# Altium schematic sheet main.SchDoc(main)
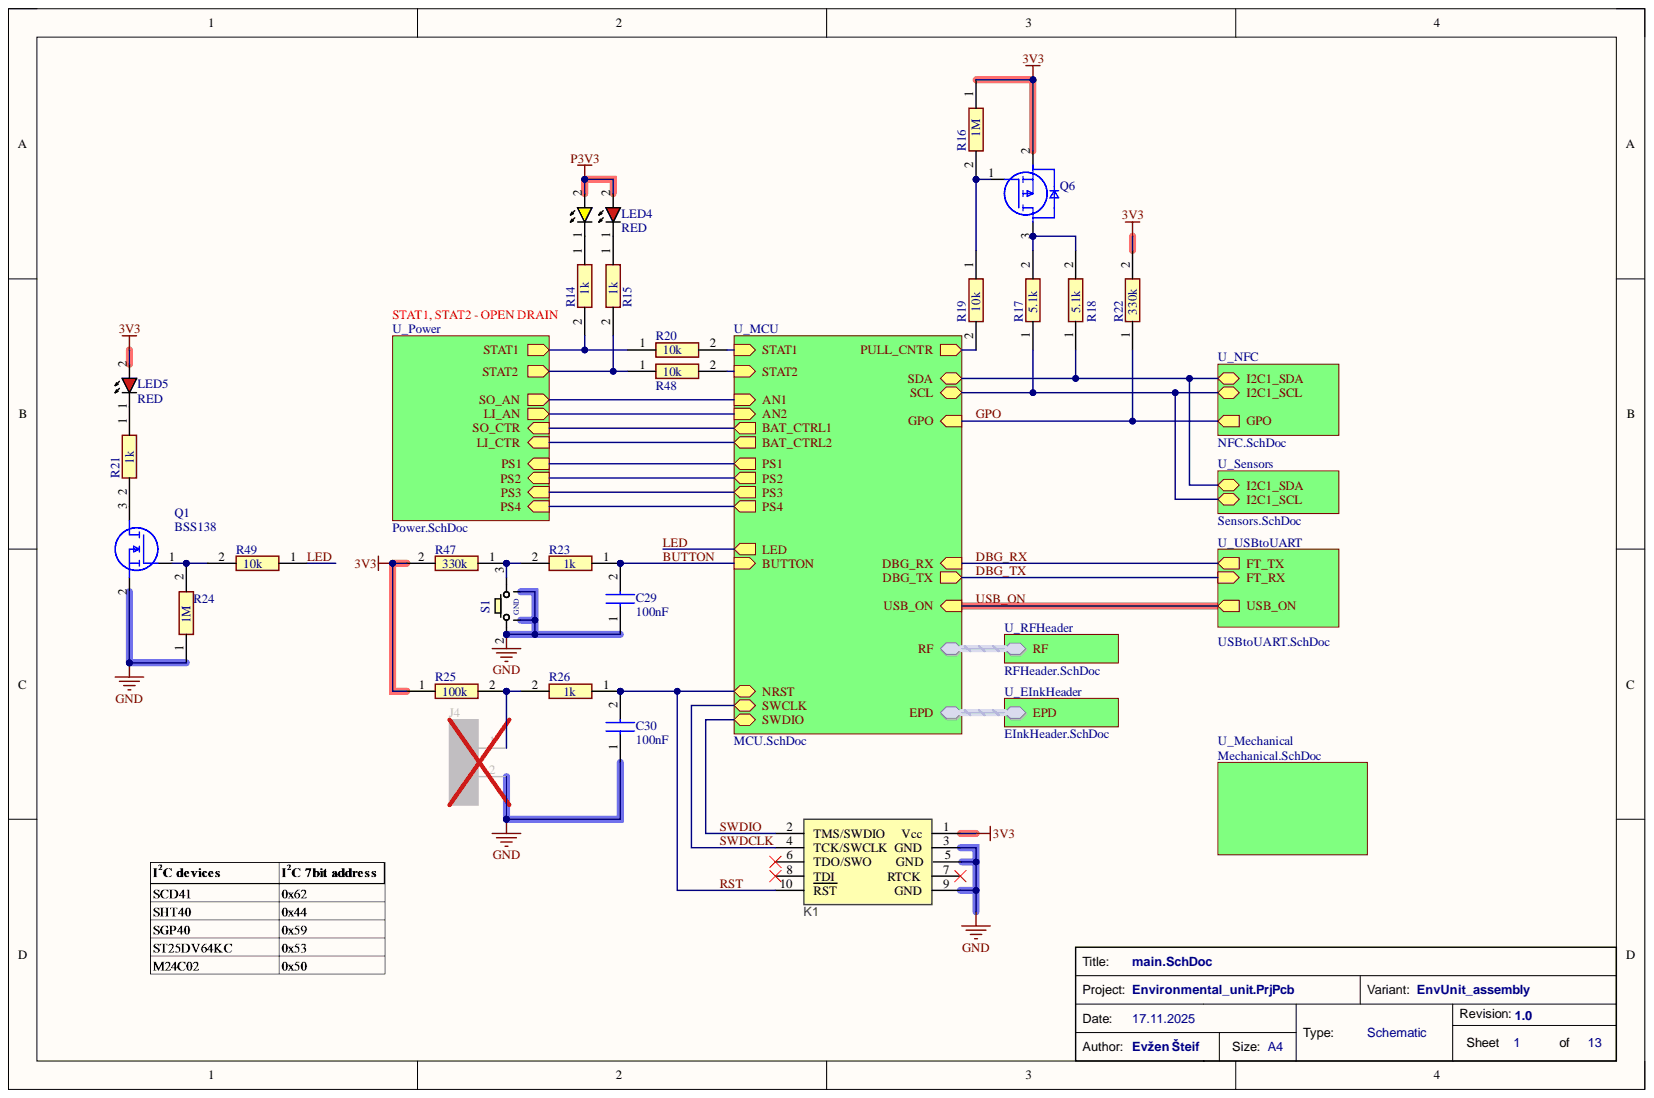
[diagram p0: main.SchDoc]

## Parts
C29  100nF  [CAPC1005X70N]
C30  100nF  [CAPC1005X70N]
J4  M20-9990246  [FP-M20-9990246-MFG]  (value not drawn)
K1  3220-10-0100-00  [TH_CON_MHDR2X5_PIT50_KEY]  (value not drawn)
LED3  150060YS75000  [LEDC1608X80N]  (value not drawn)
LED4  RED  [LEDC1608X80N]
LED5  RED  [LEDC1608X80N]
Q1  BSS138  [SOT95P240X120-3N]
Q6  SSM3J358R,LF  [SOTFL95P240X88-3N]  (value not drawn)
R14  1k  [RESC1005X35N]
R15  1k  [RESC1005X35N]
R16  1M  [RESC1005X40N]
R17  5.1k  [RESC1005X35N]
R18  5.1k  [RESC1005X35N]
R19  10k  [RESC1005X35N]
R20  10k  [RESC1005X35N]
R21  1k  [RESC1005X35N]
R22  330k  [RESC1005X40N]
R23  1k  [RESC1005X35N]
R24  1M  [RESC1005X40N]
R25  100k  [RESC1005X35N]
R26  1k  [RESC1005X35N]
R47  330k  [RESC1005X40N]
R48  10k  [RESC1005X35N]
R49  10k  [RESC1005X35N]
S1  431256038716  [431256038716]  (value not drawn)

## Nets
3V3: K1.1(Vcc) LED5.2 Q6.2 R16.1 R22.2 R25.1 R47.2
GND: C29.1 C30.1 J4.2 K1.3(GND) K1.5(GND) K1.9(GND) Q1.2 R24.1 S1.1 S1.2 S1.4
P3V3: LED3.2 LED4.2
BUTTON: C29.2 R23.1
GPO: R22.1
LED: R49.1
RST: C30.2 K1.10(RST) R26.1
SWDCLK: K1.4(TCK/SWCLK)
SWDIO: K1.2(TMS/SWDIO)
NetJ4_1: J4.1 R25.2 R26.2
NetK1_6: K1.6(TDO/SWO)
NetK1_7: K1.7(RTCK)
NetK1_8: K1.8(TDI)
NetLED3_1: LED3.1 R14.1
NetLED4_1: LED4.1 R15.1
NetLED5_1: LED5.1 R21.1
NetQ1_1: Q1.1 R24.2 R49.2
NetQ1_3: Q1.3 R21.2
NetQ6_1: Q6.1 R16.2 R19.1
NetQ6_3: Q6.3 R17.2 R18.2
NetR14_2: R14.2 R20.1
NetR15_2: R15.2 R48.1
NetR17_1: R17.1
NetR18_1: R18.1
NetR19_2: R19.2
NetR20_2: R20.2
NetR23_2: R23.2 R47.1 S1.3
NetR48_2: R48.2
AN1:
AN2:
BAT_CTRL1:
BAT_CTRL2:
DBG_RX:
DBG_TX:
EPD.EPD_BUSY:
EPD.EPD_CS:
EPD.EPD_DC:
EPD.EPD_DIN:
EPD.EPD_RST:
EPD.EPD_SCK:
PS1:
PS2:
PS3:
PS4:
RF.CS:
RF.GPIO0:
RF.GPIO1:
RF.GPIO2:
RF.MISO:
RF.MOSI:
RF.RST:
RF.RX:
RF.SCK:
RF.SCL:
RF.SDA:
RF.TX:
USB_ON:

## Library data of the parts
C29 C30 = GRM155R61A104KA01D: Capacitor; Manufacturer_Name: Murata Electronics; Arrow Part Number: GRM155R61A104KA01D; Arrow Price/Stock: https://www.arrow.com/en/products/grm155r61a104ka01d/murata-manufacturing?utm_currency=USD&region=europe; Component Kind: Standard; Datasheet Link: https://search.murata.co.jp/Ceramy/image/img/A01X/G101/ENG/GRM155R61A104KA01-02A.pdf; Height: 0.7mm; Manufacturer_Part_Number: GRM155R61A104KA01D; Mouser Part Number: 81-GRM155R61A104KA01; Mouser Price/Stock: https://www.mouser.co.uk/ProductDetail/Murata-Electronics/GRM155R61A104KA01D?qs=5bAuhL3RhmNZw0v59AqOJA%3D%3D
J4 = CMP-15831-000009-1: CONN HEADER VERT 2POS 2.54MM; Manufacturer: Harwin; Conn Gender: Male; Conn Orientation: Vertical; Current Rating: 3A; Number Of Contacts: 2; Automotive: No; Category: Conn; Component Kind: Standard; ComponentLink2Description: Datasheet; ComponentLink2URL: https://cdn.harwin.com/pdfs/M20-999.pdf; Contact Material: Tin,Nickel; Device Class L1: Connectors; Device Class L2: Headers and Wire Housings; Device Class L3: unset; Digikey Description: CONN HEADER VERT 2POS 2.54MM; Height: 8.99mm; Lead Free: Yes; Library Name: Altium Content Vault; Manufacturer Part Number: M20-9990246; Mouser Description: 2.54mm (0.1") Pitch SIL Vertical PC Tail Pin Header, 6.1mm mating pin height, tin, 2 contacts; Number Of Rows: 1; Octopart: https://octopart.com/m20-9990246-harwin-2590635; Package: M20-9990246; Pitch: 2.54mm; RoHS: TRUE; Temperature: -40°C to +105°C; Temperature Range High: +105°C; Temperature Range Low: -40°C
K1 = TEST_00015: Cortex ARM JTAG 10 Pin, Header; Manufacturer: CNC Tech; Active: 1; Autor: Krutina; Count: 585; Designer: Krahulec; Generic_replacement: No; Id: 15; Jablotron_Price: 0; LE_Price: 0.363527; Location: LE; Sourcing: Manufacturer; Cat1: JTAG; Cat2: ARM; Component Kind: Standard; ComponentLink1Description: Manufacturer Web; ComponentLink1URL: http://www.cnctech.us/; LastRevisionDate: 28.7.2015; Library Name: SamacSys.SchLib; Manufacturer Part Number: 3220-10-0100-00; PartNumber: TEST_00015; ROHS: 1; Supplier 1: Digi-Key; Supplier Part Number 1: 1175-1627-ND; Technology: THT; Validated in design: 0; Value: ARM JTAG 10; Librarian: Krahulec
LED3 = 150060YS75000: LED; Manufacturer_Name: Wurth Elektronik; Component Kind: Standard; Datasheet Link: http://uk.rs-online.com/web/p/products/8154219; Height: 0.8mm; Library Name: SamacSys.SchLib; Manufacturer_Part_Number: 150060YS75000; Mouser Part Number: 710-150060YS75000; Mouser Price/Stock: https://www.mouser.co.uk/ProductDetail/Wurth-Elektronik/150060YS75000?qs=LlUlMxKIyB0nKmwefHgtZw%3D%3D
LED4 LED5 = 150060RS55040: LED; Manufacturer_Name: Wurth Elektronik; Manufacturer_Part_Number: 150060RS75000; Component Kind: Standard; Datasheet Link: https://componentsearchengine.com/Datasheets/2/150060GS75000.pdf; Height: 0.8mm; Library Name: SamacSys.SchLib; Mouser Part Number: 710-150060RS75000; Mouser Price/Stock: https://www.mouser.co.uk/ProductDetail/Wurth-Elektronik/150060GS75000?qs=LlUlMxKIyB0UYkq5lDO8nA%3D%3D
Q1 = BSS138: MOSFET (N-Channel); Manufacturer_Name: onsemi; Arrow Part Number: BSS138; Arrow Price/Stock: https://www.arrow.com/en/products/bss138/on-semiconductor?utm_currency=USD&region=europe; Component Kind: Standard; Datasheet Link: https://www.onsemi.com/pub/Collateral/BSS138-D.PDF; Height: 1.2mm; Manufacturer_Part_Number: BSS138; Mouser Part Number: 512-BSS138; Mouser Price/Stock: https://www.mouser.co.uk/ProductDetail/onsemi-Fairchild/BSS138?qs=HK%252BeIG1iaahCeqBvjB4arg%3D%3D
Q6 = SSM3J358R,LF: MOSFET (P-Channel); Manufacturer_Name: Toshiba; Arrow Part Number: SSM3J358R,LF; Arrow Price/Stock: https://www.arrow.com/en/products/ssm3j358rlf/toshiba?region=nac; Component Kind: Standard; Datasheet Link: https://toshiba.semicon-storage.com/ap-en/product/mosfet/detail.SSM3J358R.html; Height: 0.88mm; Library Name: SamacSys.SchLib; Manufacturer_Part_Number: SSM3J358R,LF; Mouser Part Number: 757-SSM3J358RLF; Mouser Price/Stock: https://www.mouser.co.uk/ProductDetail/Toshiba/SSM3J358RLF?qs=HXFqYaX1Q2xwvCtt1GGmkw%3D%3D
R14 R15 R21 R23 R26 = RT0402FRE071KL: Resistor; Manufacturer_Name: KEMET; Arrow Part Number: RT0402FRE07100KL; Arrow Price/Stock: https://www.arrow.com/en/products/rt0402fre07100kl/yageo; Component Kind: Standard; Datasheet Link: https://www.yageo.com/upload/media/product/productsearch/datasheet/rchip/PYu-RT_1-to-0.01_RoHS_L_11.pdf; Height: 0.35mm; Library Name: SamacSys.SchLib; Manufacturer_Part_Number: RT0402FRE071KL; Mouser Part Number: RT0402FRE071KL; Mouser Price/Stock: https://www.mouser.co.uk/ProductDetail/YAGEO/RT0402FRE07100KL?qs=BXCcY9r%252B08CxTvf5JB1kiw%3D%3D
R16 R24 = RC0402FR-071ML: Resistor; Manufacturer_Name: YAGEO; Arrow Part Number: RC0402FR-071ML; Arrow Price/Stock: https://www.arrow.com/en/products/rc0402fr-071ml/yageo?region=nac; Component Kind: Standard; Datasheet Link: https://componentsearchengine.com/Datasheets/2/RC0402FR-071ML.pdf; Height: 0.4mm; Manufacturer_Part_Number: RC0402FR-071ML; Mouser Part Number: 603-RC0402FR-071ML; Mouser Price/Stock: https://www.mouser.co.uk/ProductDetail/YAGEO/RC0402FR-071ML?qs=V1yeUXFNrknfoI4987wfBQ%3D%3D
R17 R18 = RT0402FRE075K1L: Resistor; Manufacturer_Name: YAGEO; Component Kind: Standard; Datasheet Link: https://www.yageo.com/upload/media/product/app/datasheet/rchip/pyu-rt_1-to-0.01_rohs_l.pdf; Height: 0.35mm; Library Name: SamacSys.SchLib; Manufacturer_Part_Number: RT0402FRE075K1L; Mouser Part Number: RT0402FRE075K1L; Mouser Price/Stock: https://www.mouser.co.uk/ProductDetail/YAGEO/RT0402FRE07100RL?qs=BXCcY9r%252B08AcvLZjF7vcwQ%3D%3D
R19 R20 R48 R49 = RT0402FRE0710KL: Resistor; Manufacturer_Name: KEMET; Arrow Part Number: RT0402FRE07100KL; Arrow Price/Stock: https://www.arrow.com/en/products/rt0402fre07100kl/yageo; Component Kind: Standard; Datasheet Link: https://cz.mouser.com/datasheet/2/447/PYu_RT_1_to_0_01_RoHS_L_15-3461507.pdf; Height: 0.35mm; Library Name: SamacSys.SchLib; Manufacturer_Part_Number: RT0402FRE0710KL; Mouser Part Number: 603-RT0402FRE0710KL; Mouser Price/Stock: https://www.mouser.co.uk/ProductDetail/YAGEO/RT0402FRE07100KL?qs=BXCcY9r%252B08CxTvf5JB1kiw%3D%3D
R22 R47 = RC0402BR-07330KL: Resistor; Manufacturer_Name: YAGEO; Component Kind: Standard; Datasheet Link: https://cz.mouser.com/datasheet/2/447/PYu_RC_Group_51_RoHS_L_11-1984063.pdf; Height: 0.4mm; Library Name: SamacSys.SchLib; Manufacturer_Part_Number: RC0402BR-07330KL
R25 = RT0402FRE07100KL: Resistor; Manufacturer_Name: KEMET; Arrow Part Number: RT0402FRE07100KL; Arrow Price/Stock: https://www.arrow.com/en/products/rt0402fre07100kl/yageo; Component Kind: Standard; Datasheet Link: https://www.yageo.com/upload/media/product/productsearch/datasheet/rchip/PYu-RT_1-to-0.01_RoHS_L_11.pdf; Height: 0.35mm; Manufacturer_Part_Number: RT0402FRE07100KL; Mouser Part Number: 603-RT0402FRE07100KL; Mouser Price/Stock: https://www.mouser.co.uk/ProductDetail/YAGEO/RT0402FRE07100KL?qs=BXCcY9r%252B08CxTvf5JB1kiw%3D%3D
S1 = 431256038716: Switch; Manufacturer_Name: Wurth Elektronik; Component Kind: Standard; Datasheet Link: https://www.we-online.com/components/products/datasheet/431256038716.pdf; Height: 7.2mm; Library Name: SamacSys.SchLib; Manufacturer_Part_Number: 431256038716; Mouser Part Number: 710-431256038716; Mouser Price/Stock: https://www.mouser.co.uk/ProductDetail/Wurth-Elektronik/431256038716?qs=2kOmHSv6VfR5N0FN9BhJ6g%3D%3D
